# Altium schematic sheet Sheet1.SchDoc(Sheet1)
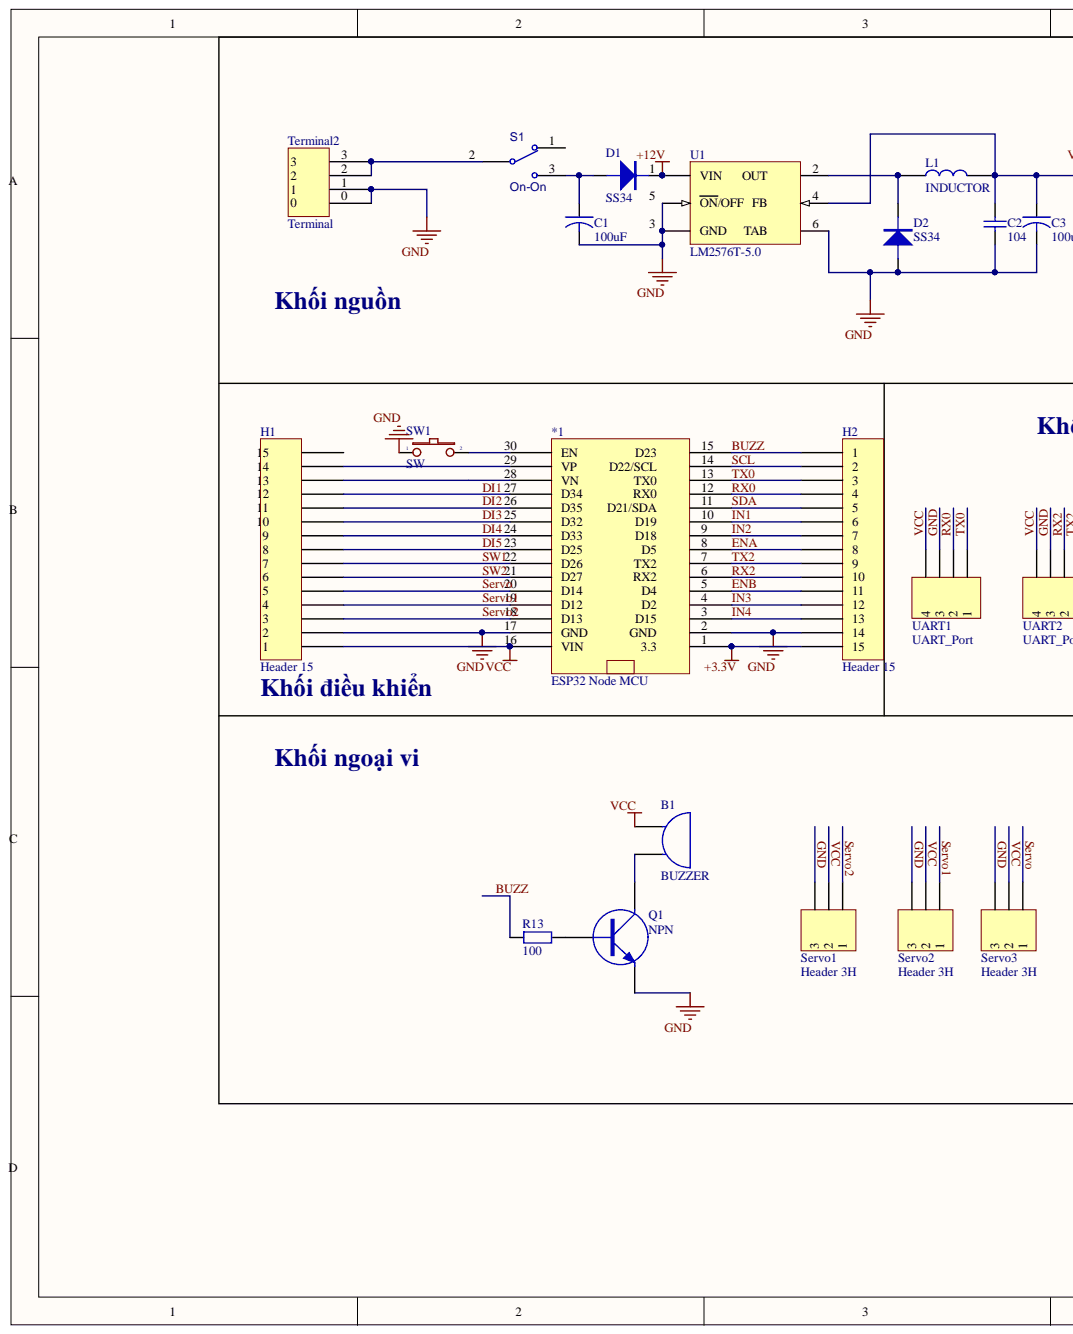
[diagram p0: Sheet1.SchDoc, left]
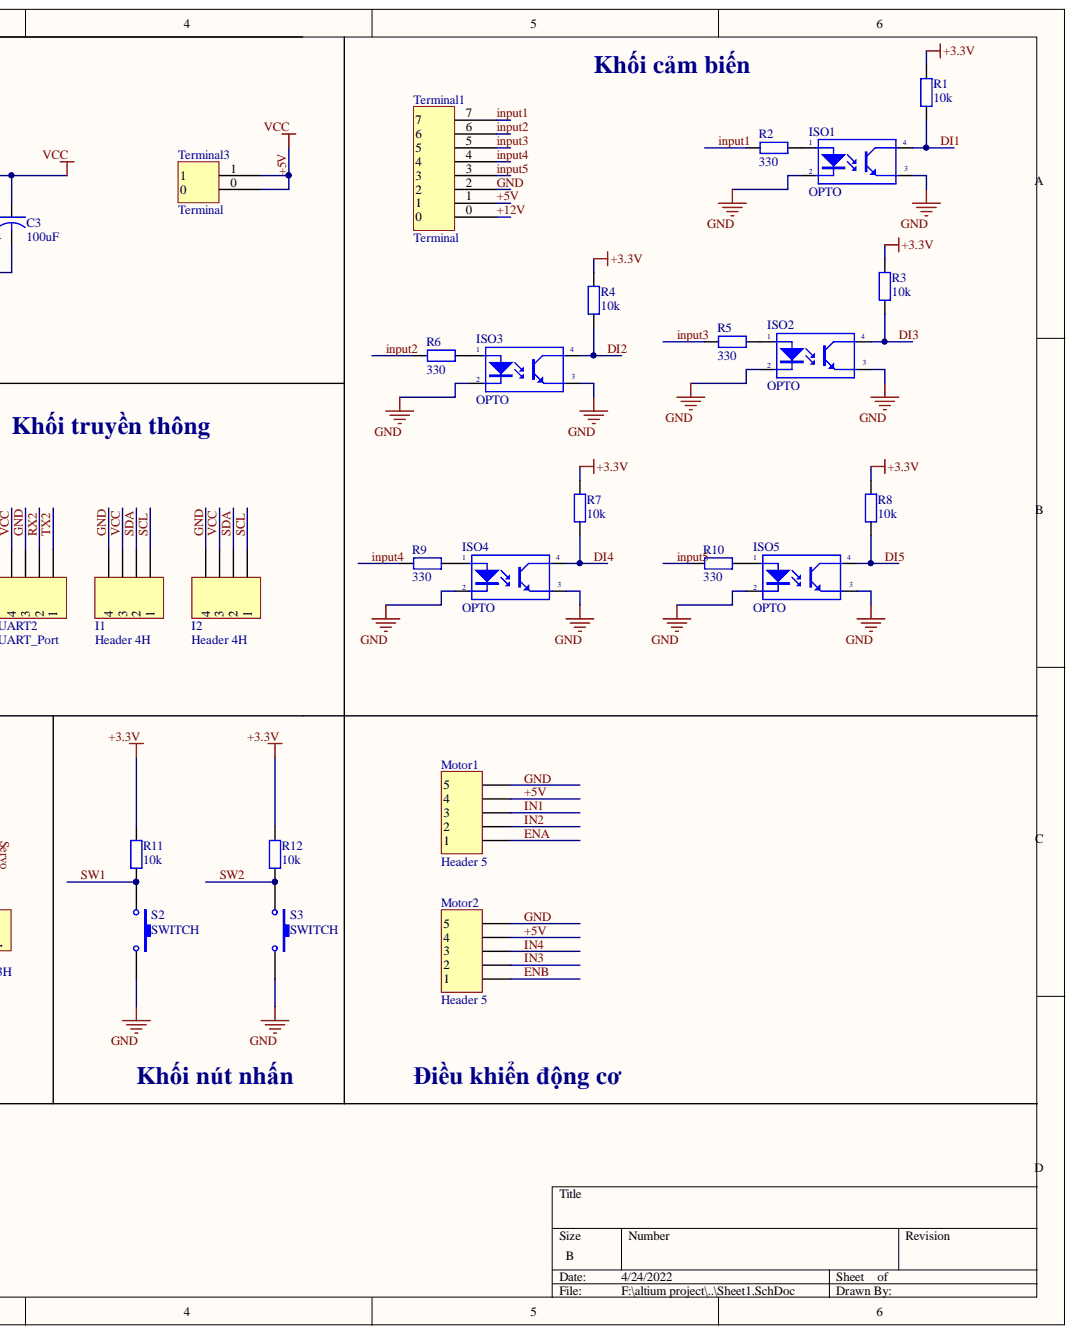
[diagram p1: Sheet1.SchDoc, right]

## Parts
*1  ESP32 Node MCU  [ESP32_node_mcu]
B1  BUZZER  [SPEAKER]
C1  100uF  [CAP RADIAL 6.3x11]
C2  104  [0805 CAP]
C3  100uF  [CAP RADIAL 6.3x11]
D1  SS34  [DIODE SMA]
D2  SS34  [DIODE SMA]
H1  Header 15  [Header 15]
H2  Header 15  [Header 15]
I1  Header 4H  [HDR1X4]
I2  Header 4H  [HDR1X4]
ISO1  OPTO  [PC817 DIP]
ISO2  OPTO  [PC817 DIP]
ISO3  OPTO  [PC817 DIP]
ISO4  OPTO  [PC817 DIP]
ISO5  OPTO  [PC817 DIP]
L1  INDUCTOR  [Inductor_SMD]
Motor1  Header 5  [HDR1X5]
Motor2  Header 5  [HDR1X5]
Q1  NPN  [TO-92]
R1  10k  [0805 RESISTOR]
R2  330  [0805 RESISTOR]
R3  10k  [0805 RESISTOR]
R4  10k  [0805 RESISTOR]
R5  330  [0805 RESISTOR]
R6  330  [0805 RESISTOR]
R7  10k  [0805 RESISTOR]
R8  10k  [0805 RESISTOR]
R9  330  [0805 RESISTOR]
R10  330  [0805 RESISTOR]
R11  10k  [R1/2W]
R12  10k  [R1/2W]
R13  100  [0805 RESISTOR]
S1  ON-ON  [SWITCH_SPDT_SLIDER]
S2  SWITCH  [SW 4PIN]
S3  SWITCH  [SW 4PIN]
Servo1  Header 3H  [HDR1X3]
Servo2  Header 3H  [HDR1X3]
Servo3  Header 3H  [HDR1X3]
SW1  SW  [SW2]
Terminal1  Terminal  [Terminal 5.08 8 pin]
Terminal2  Terminal  [Terminal 5.08 4 pin]
Terminal3  Terminal  [Terminal 5.08 2 pin]
U1  LM2576T-5.0  [TO263-5]
UART1  UART_Port  [HDR1X4]
UART2  UART_Port  [HDR1X4]

## Nets
+3.3V: *1.1 H2.15 R1.1 R3.1 R4.1 R7.1 R8.1 R11.2 R12.2
+5V: *1.16(VIN) B1.1 C2.2 C3.1 H1.1 I1.3 I2.3 L1.2 Motor1.4 Motor2.4 Servo1.2 Servo2.2 Servo3.2 Terminal1.1 Terminal3.0 Terminal3.1 U1.4 UART1.4 UART2.4
+12V: D1.2 Terminal1.0 U1.1(VIN)
BUZZ: *1.15 H2.1 R13.1
DI1: *1.27(D34) H1.12 ISO1.4 R1.2
DI2: *1.26(D35) H1.11 ISO3.4 R4.2
DI3: *1.25(D32) H1.10 ISO2.4 R3.2
DI4: *1.24(D33) H1.9 ISO4.4 R7.2
DI5: *1.23(D25) H1.8 ISO5.4 R8.2
ENA: *1.8 H2.8 Motor1.1
ENB: *1.5 H2.11 Motor2.1
GND: *1.2 *1.17(GND) C1.2 C2.1 C3.2 D2.1 H1.2 H2.14 I1.4 I2.4 ISO1.2 ISO1.3 ISO2.2 ISO2.3 ISO3.2 ISO3.3 ISO4.2 ISO4.3 ISO5.2 ISO5.3 Motor1.5 Motor2.5 Q1.1 S2.2 S3.2 Servo1.3 Servo2.3 Servo3.3 SW1.1 Terminal1.2 Terminal2.0 Terminal2.1 U1.3(GND) U1.5(ON/OFF) U1.6 UART1.3 UART2.3
IN1: *1.10 H2.6 Motor1.3
IN2: *1.9 H2.7 Motor1.2
IN3: *1.4 H2.12 Motor2.2
IN4: *1.3 H2.13 Motor2.3
INPUT1: R2.2 Terminal1.7
INPUT2: R6.2 Terminal1.6
INPUT3: R5.2 Terminal1.5
INPUT4: R9.2 Terminal1.4
INPUT5: R10.2 Terminal1.3
RX0: *1.12 H2.4 UART1.2
RX2: *1.6 H2.10 UART2.2
SCL: *1.14 H2.2 I1.1 I2.1
SDA: *1.11 H2.5 I1.2 I2.2
SERVO: *1.20(D14) H1.5 Servo3.1
SERVO1: *1.19(D12) H1.4 Servo2.1
SERVO2: *1.18(D13) H1.3 Servo1.1
SW1: *1.22(D26) H1.7 R11.1 S2.1
SW2: *1.21(D27) H1.6 R12.1 S3.1
TX0: *1.13 H2.3 UART1.1
TX2: *1.7 H2.9 UART2.1
Net*1_28: *1.28(VN) H1.13
Net*1_29: *1.29(VP) H1.14
Net*1_30: *1.30(EN) SW1.2
NetB1_2: B1.2 Q1.2
NetC1_1: C1.1 D1.1 S1.3
NetD2_2: D2.2 L1.1 U1.2
NetISO1_1: ISO1.1 R2.1
NetISO2_1: ISO2.1 R5.1
NetISO3_1: ISO3.1 R6.1
NetISO4_1: ISO4.1 R9.1
NetISO5_1: ISO5.1 R10.1
NetQ1_3: Q1.3 R13.2
NetS1_2: S1.2 Terminal2.2 Terminal2.3

Not connected: H1 15; S1 1

## Library data of the parts
C1 C3 = CAPACITOR: Component Kind: Standard; Library Name: ThinhNguyenLib.SchLib
C2 = CAP CERAMIC: Component Kind: Standard; LatestRevisionDate: 17-Jul-2002; LatestRevisionNote: Re-released for DXP Platform.; PackageReference: RAD-0.3; Published: 8-Jun-2000; Library Name: ThinhNguyenLib.SchLib; Publisher: Altium Limited
D1 D2 = DIODE: Component Kind: Standard; Library Name: ThinhNguyenLib.SchLib
ISO1-ISO5 = OPTO: Value: TLP5211; Component Kind: Standard; Library Name: ThinhNguyenLib.SchLib
R1-R10 R13 = RESISTOR: Value: R1/4W - 1K; Component Kind: Standard; Library Name: ThinhNguyenLib.SchLib
R11 R12 = R1/4W: Resistor 1/4W; Value: R1/4W - 1K; Component Kind: Standard; Library Name: hphonglib.SchLib
S1 = SW_THD_SPDT_On-On: Switch THD vertical toggle SPDT; Manufacturer 1: TE Connectivity; Manufacturer Part Number 1: SLS121PC04; Contact Rating @ Voltage: 0.25A @ 125VAC; SCDType: spec; Circuit: SPDT; Component Kind: Standard; Library Name: Smart_home_v3.SCHLIB; Mounting Type: PCB mount; Supplier 1: Digi-Key; Supplier Part Number 1: 450-1598-ND; Switch Function: On-On
SW1 = SW2: Switch button 2 pin; Value: SW chip 2 pin; Component Kind: Standard; Library Name: syhaunguyen.IntLib
Terminal1 = Terminal 5.08 8 pin: Component Kind: Standard; Library Name: ThinhNguyenLib.SchLib
Terminal2 = Terminal 5.08 4 pin: Component Kind: Standard; Library Name: ThinhNguyenLib.SchLib
Terminal3 = Terminal 5.08 2pin: Component Kind: Standard; Library Name: ThinhNguyenLib.SchLib
U1 = LM2576T-5.0: Manufacturer: National Semiconductor; CompType: Switcher; PackageTopMarking: NSUZXYTT*LM2576T*-5.0 P+; PowerWise: N; Code_JEDEC: TO-220-AB; Component Kind: Standard; ComponentLink1Description: LM2576 Datasheet; ComponentLink1URL: http://www.national.com/ds/LM/LM2576.pdf; ComponentLink2Description: LM2576 Web Page; ComponentLink2URL: http://www.national.com/pf/LM/LM2576.html; ComponentLink3Description: T05A Package Page; ComponentLink3URL: http://www.national.com/packaging/folders/t05a.html; DatasheetVersion: Aug-2004; DigiKeyPartNumber: LM2576T-5.0-ND; FirstPublished: 4-Dec-2008; PackageDescription: TO-220, Molded, 5 Lead, Straight; PackageReference: T05A; PackageVersion: revJ, Jan-1992; PartNumber: LM2576T-5.0; PinCount: 5; RoHS: O; Library Name: ThinhNguyenLib.SchLib; Publisher: Altium Limited
UART1 UART2 = Header 4H: Component Kind: Standard; LatestRevisionDate: 17-Jul-2002; LatestRevisionNote: Re-released for DXP Platform.; Library Name: ThinhNguyenLib.SchLib; Publisher: Altium Limited
*1 = ESP32 Node MCU: Component Kind: Standard; Library Name: ThinhNguyenLib.SchLib
B1 = BUZZER: Component Kind: Standard; Library Name: ThinhNguyenLib.SchLib
H1 H2 = Header 15: Component Kind: Standard; Library Name: ThinhNguyenLib.SchLib
I1 I2 = Header 4H: Component Kind: Standard; LatestRevisionDate: 17-Jul-2002; LatestRevisionNote: Re-released for DXP Platform.; Library Name: ThinhNguyenLib.SchLib; Publisher: Altium Limited
L1 = INDUCTOR: Component Kind: Standard; Library Name: ThinhNguyenLib.SchLib
Motor1 Motor2 = Header 5: Component Kind: Standard; LatestRevisionDate: 17-Jul-2002; LatestRevisionNote: Re-released for DXP Platform.; Library Name: ThinhNguyenLib.SchLib; Publisher: Altium Limited
Q1 = NPN: Component Kind: Standard; Library Name: ThinhNguyenLib.SchLib
S2 S3 = SWITCH: Component Kind: Standard; LatestRevisionDate: 17-Jul-2002; LatestRevisionNote: Re-released for DXP Platform.; PackageReference: SPST-2; Published: 24-Mar-1999; Library Name: ThinhNguyenLib.IntLib; Publisher: Altium Limited
Servo1-Servo3 = Header 3H: Component Kind: Standard; LatestRevisionDate: 17-Jul-2002; LatestRevisionNote: Re-released for DXP Platform.; Library Name: ThinhNguyenLib.IntLib; Publisher: Altium Limited
